# Altium schematic sheet S05_CPU_RevF.SchDoc
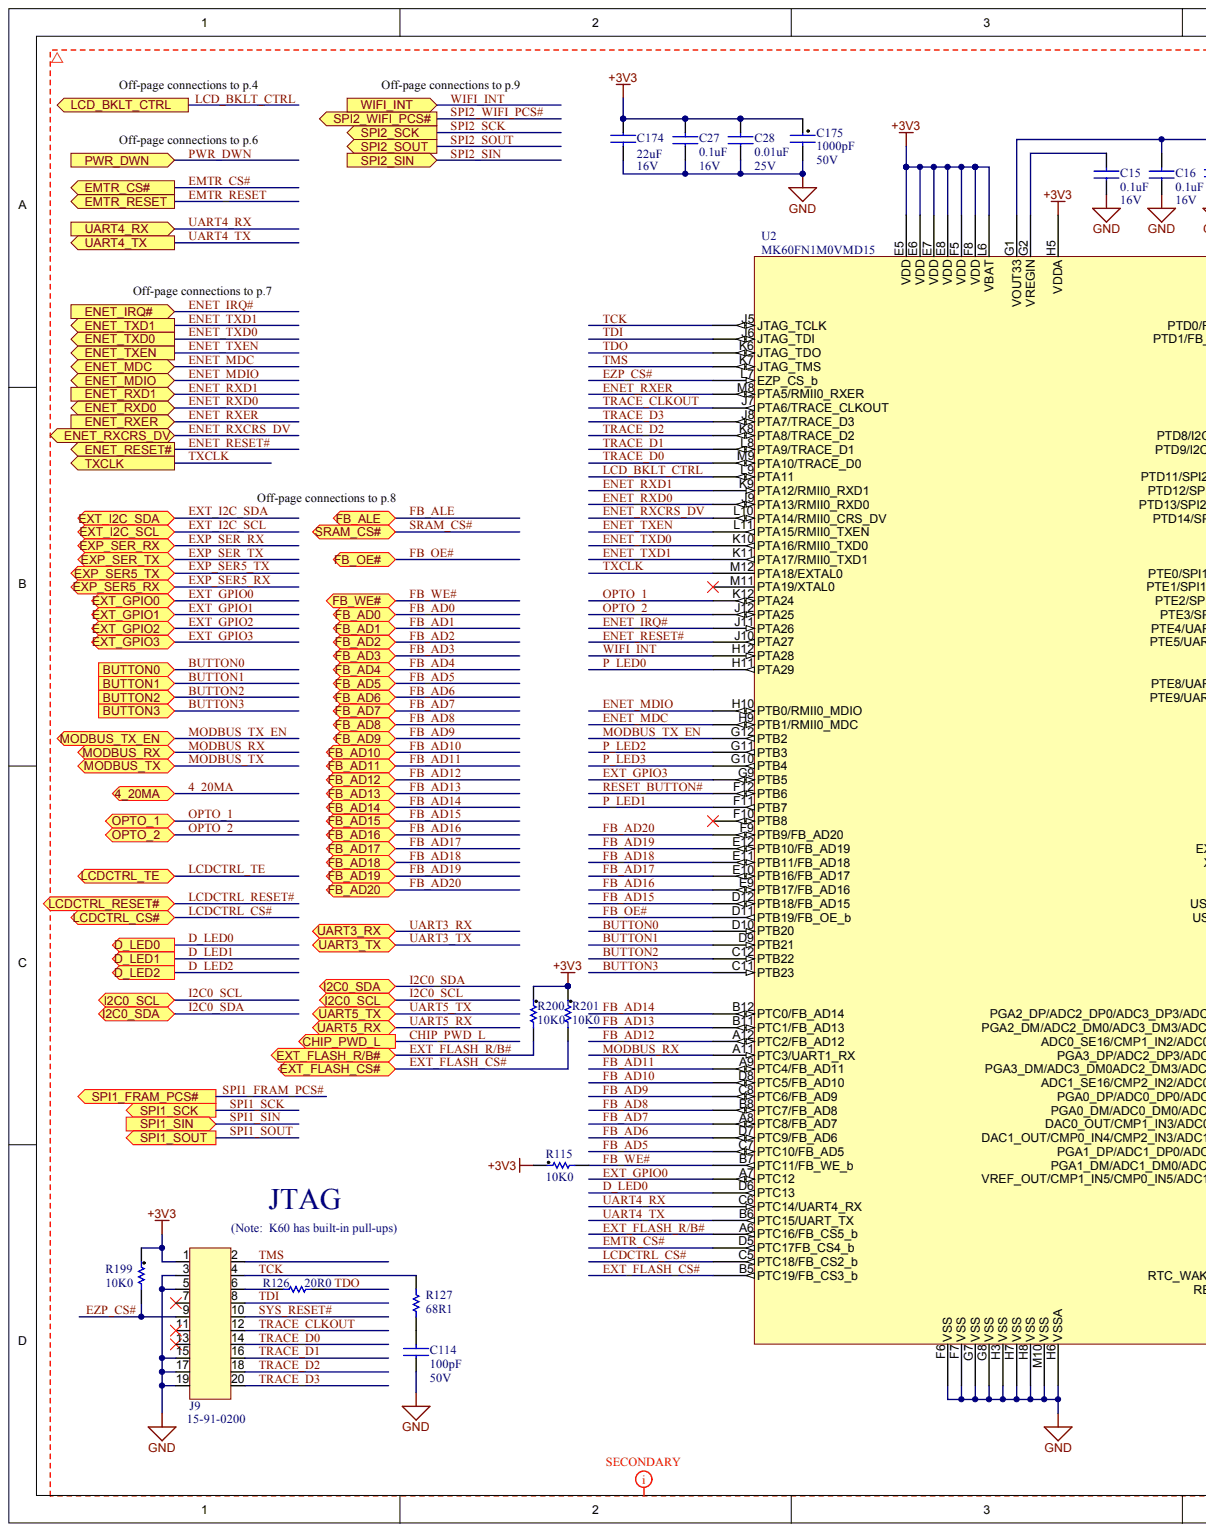
[diagram p0: S05_CPU_RevF.SchDoc, left]
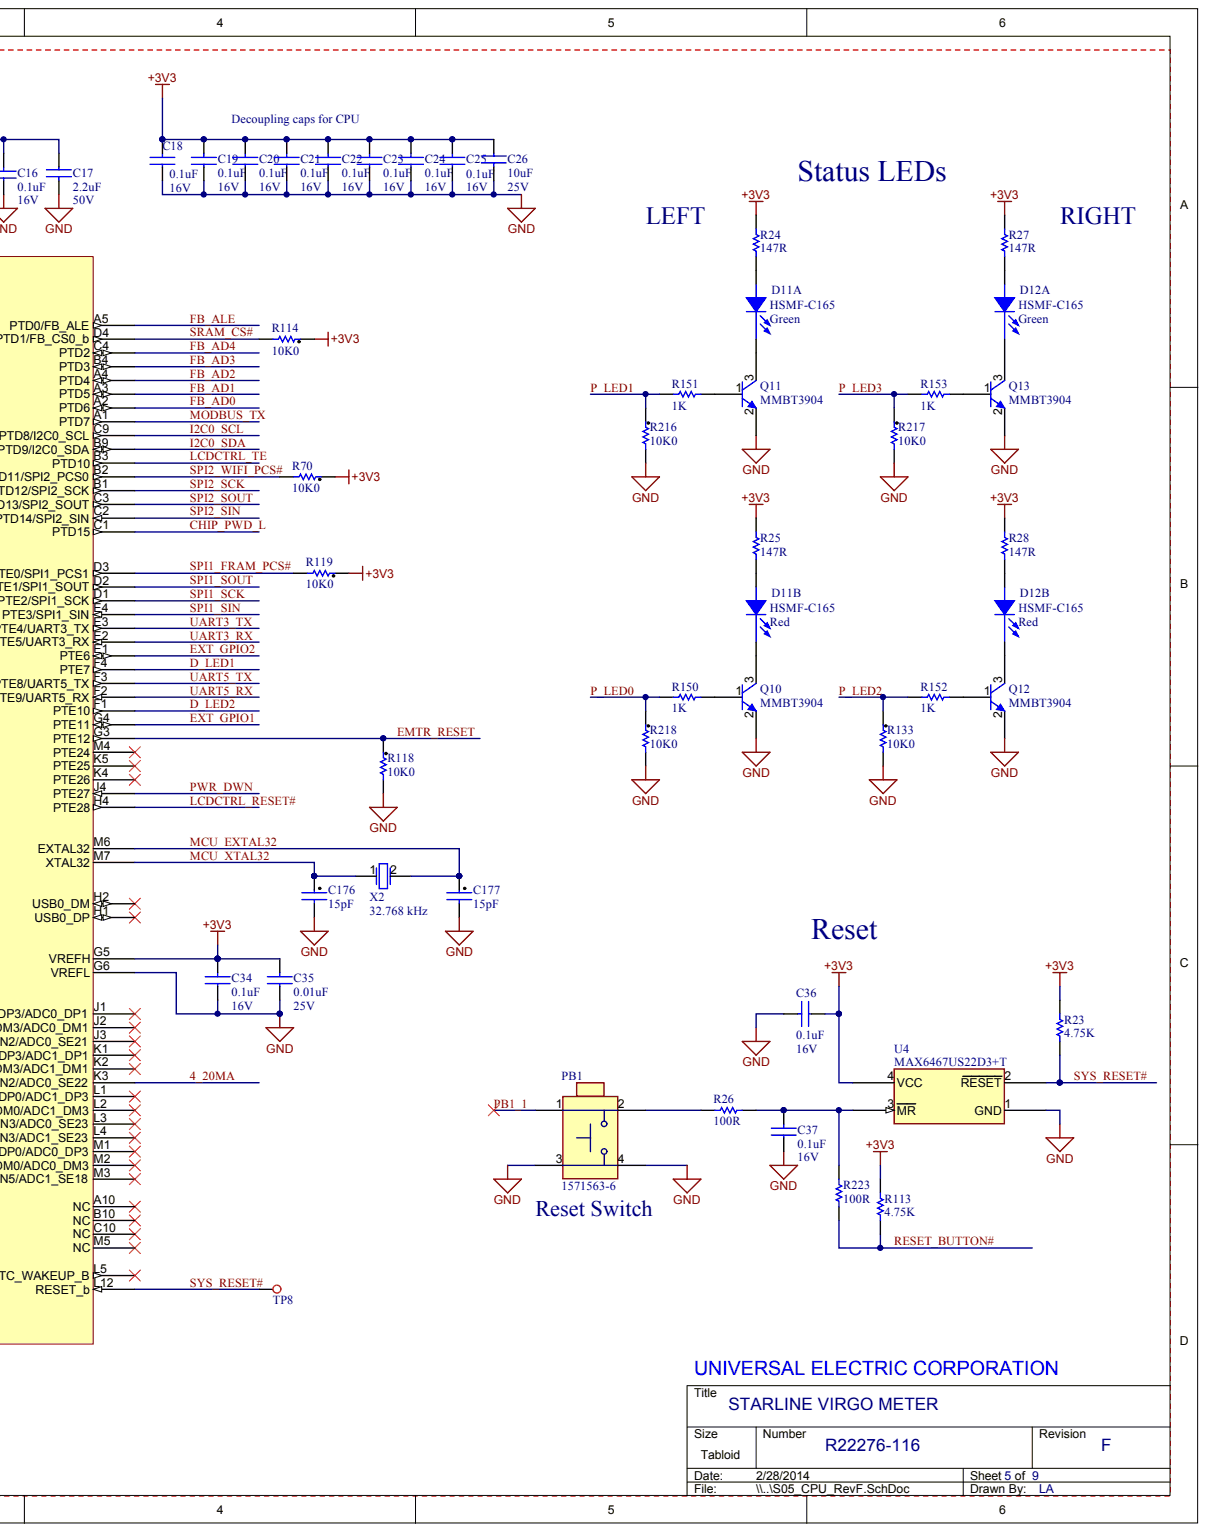
[diagram p1: S05_CPU_RevF.SchDoc, right]

## Parts
C15  value 0.1uF  Voltage: 16V  (hidden comment: CAP CER 0.1uF X5R 10% 16V SM_0402)
C16  value 0.1uF  Voltage: 16V  (hidden comment: CAP CER 0.1uF X5R 10% 16V SM_0402)
C17  Voltage: 50V  (hidden comment: 2.2uF)
C18  value 0.1uF  Voltage: 16V  (hidden comment: CAP CER 0.1uF X5R 10% 16V SM_0402)
C19  value 0.1uF  Voltage: 16V  (hidden comment: CAP CER 0.1uF X5R 10% 16V SM_0402)
C20  value 0.1uF  Voltage: 16V  (hidden comment: CAP CER 0.1uF X5R 10% 16V SM_0402)
C21  value 0.1uF  Voltage: 16V  (hidden comment: CAP CER 0.1uF X5R 10% 16V SM_0402)
C22  value 0.1uF  Voltage: 16V  (hidden comment: CAP CER 0.1uF X5R 10% 16V SM_0402)
C23  value 0.1uF  Voltage: 16V  (hidden comment: CAP CER 0.1uF X5R 10% 16V SM_0402)
C24  value 0.1uF  Voltage: 16V  (hidden comment: CAP CER 0.1uF X5R 10% 16V SM_0402)
C25  value 0.1uF  Voltage: 16V  (hidden comment: CAP CER 0.1uF X5R 10% 16V SM_0402)
C26  Voltage: 25V  (hidden comment: 10uF)
C27  value 0.1uF  Voltage: 16V  (hidden comment: CAP CER 0.1uF X5R 10% 16V SM_0402)
C28  value 0.01uF  Voltage: 25V  (hidden comment: CAP CER 0.01uF X7R 10% 25V SM_0402)
C34  value 0.1uF  Voltage: 16V  (hidden comment: CAP CER 0.1uF X5R 10% 16V SM_0402)
C35  value 0.01uF  Voltage: 25V  (hidden comment: CAP CER 0.01uF X7R 10% 25V SM_0402)
C36  value 0.1uF  Voltage: 16V  (hidden comment: CAP CER 0.1uF X5R 10% 16V SM_0402)
C37  value 0.1uF  Voltage: 16V  (hidden comment: CAP CER 0.1uF X5R 10% 16V SM_0402)
C114  value 100pF  Voltage: 50V  (hidden comment: CAP CER 100pF C0G 5% 50V SM_0402)
C174  Voltage: 16V  (hidden comment: 22uF)
C175  Voltage: 50V  (hidden comment: 1000pF)
C176  15pF
C177  15pF
D11  MFG_PART_NO: HSMF-C165  (hidden comment: Green)  (parts A,B)
D12  MFG_PART_NO: HSMF-C165  (hidden comment: Green)  (parts A,B)
J9  MFG_PART_NO2: 15-91-0200  (hidden comment: NUV_20CONN_Dual)
PB1  1571563-6
Q10  MMBT3904
Q11  MMBT3904
Q12  MMBT3904
Q13  MMBT3904
R23  value 4.75K  (hidden comment: RES 4.75K 1/16W 1% SM_0402)
R24  value 147R  (hidden comment: RES 147R 1/16W 1% SM_0402)
R25  value 147R  (hidden comment: RES 147R 1/16W 1% SM_0402)
R26  value 100R  (hidden comment: RES 100R 1/16W 1% SM_0402)
R27  value 147R  (hidden comment: RES 147R 1/16W 1% SM_0402)
R28  value 147R  (hidden comment: RES 147R 1/16W 1% SM_0402)
R70  10K0
R113  value 4.75K  (hidden comment: RES 4.75K 1/16W 1% SM_0402)
R114  10K0
R115  10K0
R118  10K0
R119  10K0
R126  value 20R0  (hidden comment: RES 20R0 1/16W 1% SM_0402)
R127  value 68R1  (hidden comment: RES 68R1 1/16W 1% SM_0402)
R133  10K0
R150  value 1K  (hidden comment: RES 1K 1/16W 1% SM_0402)
R151  value 1K  (hidden comment: RES 1K 1/16W 1% SM_0402)
R152  value 1K  (hidden comment: RES 1K 1/16W 1% SM_0402)
R153  value 1K  (hidden comment: RES 1K 1/16W 1% SM_0402)
R199  10K0
R200  10K0
R201  10K0
R216  10K0
R217  10K0
R218  10K0
R223  value 100R  (hidden comment: RES 100R 1/16W 1% SM_0402)
TP8  =VALUE
U2  MK60FN1M0VMD15
U4  MFG_PART_NO: MAX6467US22D3+T
X2  32.768 kHz

## Ports
4_20MA, BUTTON0, BUTTON1, BUTTON2, BUTTON3, CHIP_PWD_L, D_LED0, D_LED1, D_LED2, EMTR_CS#, EMTR_RESET, ENET_IRQ#, ENET_MDC, ENET_MDIO, ENET_RESET#, ENET_RXCRS_DV, ENET_RXD0, ENET_RXD1, ENET_RXER, ENET_TXD0, ENET_TXD1, ENET_TXEN, EXP_SER5_RX, EXP_SER5_TX, EXP_SER_RX, EXP_SER_TX, EXT_FLASH_CS#, EXT_FLASH_R/B#, EXT_GPIO0, EXT_GPIO1, EXT_GPIO2, EXT_GPIO3, EXT_I2C_SCL, EXT_I2C_SDA, FB_AD0, FB_AD1, FB_AD2, FB_AD3, FB_AD4, FB_AD5, FB_AD6, FB_AD7, FB_AD8, FB_AD9, FB_AD10, FB_AD11, FB_AD12, FB_AD13, FB_AD14, FB_AD15, FB_AD16, FB_AD17, FB_AD18, FB_AD19, FB_AD20, FB_ALE, FB_OE#, FB_WE#, I2C0_SCL, I2C0_SDA, LCD_BKLT_CTRL, LCDCTRL_CS#, LCDCTRL_RESET#, LCDCTRL_TE, MODBUS_RX, MODBUS_TX, MODBUS_TX_EN, OPTO_1, OPTO_2, PWR_DWN, SPI1_FRAM_PCS#, SPI1_SCK, SPI1_SIN, SPI1_SOUT, SPI2_SCK, SPI2_SIN, SPI2_SOUT, SPI2_WIFI_PCS#, SRAM_CS#, TXCLK, UART3_RX, UART3_TX, UART4_RX, UART4_TX, UART5_RX, UART5_TX, WIFI_INT

## Nets
+3V3: C18.2 C19.2 C20.2 C21.2 C22.2 C23.2 C24.2 C25.2 C26.1 C27.2 C28.2 C34.2 C35.2 C36.1 C174.1 C175.1 J9.1 R23.2 R24.2 R25.2 R27.2 R28.2 R70.1 R113.2 R114.1 R115.1 R119.1 R199.1 R200.1 R201.1 U2.E5(VDD) U2.E6(VDD) U2.E7(VDD) U2.E8(VDD) U2.F5(VDD) U2.F8(VDD) U2.G5(VREFH) U2.H5(VDDA) U2.L6(VBAT) U4.4(VCC)
GND: C15.1 C16.1 C17.2 C18.1 C19.1 C20.1 C21.1 C22.1 C23.1 C24.1 C25.1 C26.2 C27.1 C28.1 C34.1 C35.1 C36.2 C37.1 C114.1 C174.2 C175.2 C176.2 C177.2 J9.3 J9.5 J9.15 J9.17 J9.19 PB1.3 PB1.4 Q10.2 Q11.2 Q12.2 Q13.2 R118.2 R133.2 R216.2 R217.2 R218.2 U2.F6(VSS) U2.F7(VSS) U2.G6(VREFL) U2.G7(VSS) U2.G8(VSS) U2.H3(VSS) U2.H6(VSSA) U2.H7(VSS) U2.H8(VSS) U2.M10(VSS) U4.1(GND)
4_20MA: U2.K3(ADC1_SE16/CMP2_IN2/ADC0_SE22) ; port 4_20MA
BUTTON0: U2.D10(PTB20) ; port BUTTON0
BUTTON1: U2.D9(PTB21) ; port BUTTON1
BUTTON2: U2.C12(PTB22) ; port BUTTON2
BUTTON3: U2.C11(PTB23) ; port BUTTON3
CHIP_PWD_L: U2.C1(PTD15) ; port CHIP_PWD_L
D_LED0: U2.D6(PTC13) ; port D_LED0
D_LED1: U2.F4(PTE7) ; port D_LED1
D_LED2: U2.F1(PTE10) ; port D_LED2
EMTR_CS#: U2.D5(PTC17FB_CS4_b) ; port EMTR_CS#
EMTR_RESET: R118.1 U2.G3(PTE12) ; port EMTR_RESET
ENET_IRQ#: U2.J11(PTA26) ; port ENET_IRQ#
ENET_MDC: U2.H9(PTB1/RMII0_MDC) ; port ENET_MDC
ENET_MDIO: U2.H10(PTB0/RMII0_MDIO) ; port ENET_MDIO
ENET_RESET#: U2.J10(PTA27) ; port ENET_RESET#
ENET_RXCRS_DV: U2.L10(PTA14/RMII0_CRS_DV) ; port ENET_RXCRS_DV
ENET_RXD0: U2.J9(PTA13/RMII0_RXD0) ; port ENET_RXD0
ENET_RXD1: U2.K9(PTA12/RMII0_RXD1) ; port ENET_RXD1
ENET_RXER: U2.M8(PTA5/RMII0_RXER) ; port ENET_RXER
ENET_TXD0: U2.K10(PTA16/RMII0_TXD0) ; port ENET_TXD0
ENET_TXD1: U2.K11(PTA17/RMII0_TXD1) ; port ENET_TXD1
ENET_TXEN: U2.L11(PTA15/RMII0_TXEN) ; port ENET_TXEN
EXT_FLASH_CS#: R201.2 U2.B5(PTC19/FB_CS3_b) ; port EXT_FLASH_CS#
EXT_FLASH_R/B#: R200.2 U2.A6(PTC16/FB_CS5_b) ; port EXT_FLASH_R/B#
EXT_GPIO0: U2.A7(PTC12) ; port EXT_GPIO0
EXT_GPIO1: U2.G4(PTE11) ; port EXT_GPIO1
EXT_GPIO2: U2.E1(PTE6) ; port EXT_GPIO2
EXT_GPIO3: U2.G9(PTB5) ; port EXT_GPIO3
EZP_CS#: J9.9 R199.2 U2.L7(EZP_CS_b)
FB_AD0: U2.A2(PTD6) ; port FB_AD0
FB_AD1: U2.A3(PTD5) ; port FB_AD1
FB_AD2: U2.A4(PTD4) ; port FB_AD2
FB_AD3: U2.B4(PTD3) ; port FB_AD3
FB_AD4: U2.C4(PTD2) ; port FB_AD4
FB_AD5: U2.C7(PTC10/FB_AD5) ; port FB_AD5
FB_AD6: U2.D7(PTC9/FB_AD6) ; port FB_AD6
FB_AD7: U2.A8(PTC8/FB_AD7) ; port FB_AD7
FB_AD8: U2.B8(PTC7/FB_AD8) ; port FB_AD8
FB_AD9: U2.C8(PTC6/FB_AD9) ; port FB_AD9
FB_AD10: U2.D8(PTC5/FB_AD10) ; port FB_AD10
FB_AD11: U2.A9(PTC4/FB_AD11) ; port FB_AD11
FB_AD12: U2.A12(PTC2/FB_AD12) ; port FB_AD12
FB_AD13: U2.B11(PTC1/FB_AD13) ; port FB_AD13
FB_AD14: U2.B12(PTC0/FB_AD14) ; port FB_AD14
FB_AD15: U2.D12(PTB18/FB_AD15) ; port FB_AD15
FB_AD16: U2.E9(PTB17/FB_AD16) ; port FB_AD16
FB_AD17: U2.E10(PTB16/FB_AD17) ; port FB_AD17
FB_AD18: U2.E11(PTB11/FB_AD18) ; port FB_AD18
FB_AD19: U2.E12(PTB10/FB_AD19) ; port FB_AD19
FB_AD20: U2.F9(PTB9/FB_AD20) ; port FB_AD20
FB_ALE: U2.A5(PTD0/FB_ALE) ; port FB_ALE
FB_OE#: U2.D11(PTB19/FB_OE_b) ; port FB_OE#
FB_WE#: R115.2 U2.B7(PTC11/FB_WE_b) ; port FB_WE#
I2C0_SCL: U2.C9(PTD8/I2C0_SCL) ; port I2C0_SCL
I2C0_SDA: U2.B9(PTD9/I2C0_SDA) ; port I2C0_SDA
LCD_BKLT_CTRL: U2.L9(PTA11) ; port LCD_BKLT_CTRL
LCDCTRL_CS#: U2.C5(PTC18/FB_CS2_b) ; port LCDCTRL_CS#
LCDCTRL_RESET#: U2.H4(PTE28) ; port LCDCTRL_RESET#
LCDCTRL_TE: U2.B3(PTD10) ; port LCDCTRL_TE
MCU_EXTAL32: C177.1 U2.M6(EXTAL32) X2.2
MCU_XTAL32: C176.1 U2.M7(XTAL32) X2.1
MODBUS_RX: U2.A11(PTC3/UART1_RX) ; port MODBUS_RX
MODBUS_TX: U2.A1(PTD7) ; port MODBUS_TX
MODBUS_TX_EN: U2.G12(PTB2) ; port MODBUS_TX_EN
OPTO_1: U2.K12(PTA24) ; port OPTO_1
OPTO_2: U2.J12(PTA25) ; port OPTO_2
P_LED0: R150.1 R218.1 U2.H11(PTA29)
P_LED1: R151.1 R216.1 U2.F11(PTB7)
P_LED2: R133.1 R152.1 U2.G11(PTB3)
P_LED3: R153.1 R217.1 U2.G10(PTB4)
PB1_1: PB1.1
PWR_DWN: U2.J4(PTE27) ; port PWR_DWN
RESET_BUTTON#: R113.1 R223.1 U2.F12(PTB6)
SPI1_FRAM_PCS#: R119.2 U2.D3(PTE0/SPI1_PCS1) ; port SPI1_FRAM_PCS#
SPI1_SCK: U2.D1(PTE2/SPI1_SCK) ; port SPI1_SCK
SPI1_SIN: U2.E4(PTE3/SPI1_SIN) ; port SPI1_SIN
SPI1_SOUT: U2.D2(PTE1/SPI1_SOUT) ; port SPI1_SOUT
SPI2_SCK: U2.B1(PTD12/SPI2_SCK) ; port SPI2_SCK
SPI2_SIN: U2.C2(PTD14/SPI2_SIN) ; port SPI2_SIN
SPI2_SOUT: U2.C3(PTD13/SPI2_SOUT) ; port SPI2_SOUT
SPI2_WIFI_PCS#: R70.2 U2.B2(PTD11/SPI2_PCS0) ; port SPI2_WIFI_PCS#
SRAM_CS#: R114.2 U2.D4(PTD1/FB_CS0_b) ; port SRAM_CS#
SYS_RESET#: J9.10 R23.1 TP8.1 U2.L12(RESET_b) U4.2(RESET)
TCK: J9.4 R127.2 U2.J5(JTAG_TCLK)
TDI: J9.8 U2.J6(JTAG_TDI)
TDO: R126.2 U2.K6(JTAG_TDO)
TMS: J9.2 U2.K7(JTAG_TMS)
TRACE_CLKOUT: J9.12 U2.J7(PTA6/TRACE_CLKOUT)
TRACE_D0: J9.14 U2.M9(PTA10/TRACE_D0)
TRACE_D1: J9.16 U2.L8(PTA9/TRACE_D1)
TRACE_D2: J9.18 U2.K8(PTA8/TRACE_D2)
TRACE_D3: J9.20 U2.J8(PTA7/TRACE_D3)
TXCLK: U2.M12(PTA18/EXTAL0) ; port TXCLK
UART3_RX: U2.E2(PTE5/UART3_RX) ; port UART3_RX
UART3_TX: U2.E3(PTE4/UART3_TX) ; port UART3_TX
UART4_RX: U2.C6(PTC14/UART4_RX) ; port UART4_RX
UART4_TX: U2.B6(PTC15/UART_TX) ; port UART4_TX
UART5_RX: U2.F2(PTE9/UART5_RX) ; port UART5_RX
UART5_TX: U2.F3(PTE8/UART5_TX) ; port UART5_TX
WIFI_INT: U2.H12(PTA28) ; port WIFI_INT
NetC15_2: C15.2 U2.G2(VREGIN)
NetC16_2: C16.2 C17.1 U2.G1(VOUT33)
NetC37_2: C37.2 R26.2 R223.2 U4.3(MR)
NetC114_2: C114.2 R127.1
NetD11_A1: D11.A1 R24.1
NetD11_A2: D11.A2 R25.1
NetD11_K1: D11.K1 Q11.3
NetD11_K2: D11.K2 Q10.3
NetD12_A1: D12.A1 R27.1
NetD12_A2: D12.A2 R28.1
NetD12_K1: D12.K1 Q13.3
NetD12_K2: D12.K2 Q12.3
NetJ9_6: J9.6 R126.1
NetPB1_2: PB1.2 R26.1
NetQ10_1: Q10.1 R150.2
NetQ11_1: Q11.1 R151.2
NetQ12_1: Q12.1 R152.2
NetQ13_1: Q13.1 R153.2
EXP_SER5_RX: ; port EXP_SER5_RX
EXP_SER5_TX: ; port EXP_SER5_TX
EXP_SER_RX: ; port EXP_SER_RX
EXP_SER_TX: ; port EXP_SER_TX
EXT_I2C_SCL: ; port EXT_I2C_SCL
EXT_I2C_SDA: ; port EXT_I2C_SDA
N00176:

Not connected: J9 7 11 13; U2 A10(NC) B10(NC) C10(NC) F10(PTB8) H1(USB0_DP) H2(USB0_DM) J1(PGA2_DP/ADC2_DP0/ADC3_DP3/ADC0_DP1) J2(PGA2_DM/ADC2_DM0/ADC3_DM3/ADC0_DM1) J3(ADC0_SE16/CMP1_IN2/ADC0_SE21) K1(PGA3_DP/ADC2_DP3/ADC1_DP1) K2(PGA3_DM/ADC3_DM0ADC2_DM3/ADC1_DM1) K4(PTE26) K5(PTE25) L1(PGA0_DP/ADC0_DP0/ADC1_DP3) L2(PGA0_DM/ADC0_DM0/ADC1_DM3) L3(DAC0_OUT/CMP1_IN3/ADC0_SE23) L4(DAC1_OUT/CMP0_IN4/CMP2_IN3/ADC1_SE23) L5(RTC_WAKEUP_B) M1(PGA1_DP/ADC1_DP0/ADC0_DP3) M2(PGA1_DM/ADC1_DM0/ADC0_DM3) M3(VREF_OUT/CMP1_IN5/CMP0_IN5/ADC1_SE18) M4(PTE24) M5(NC) M11(PTA19/XTAL0)

## Library data of the parts
C15 C16 C18-C25 C27 C34 C36 C37 = (no symbol name): MFG_PART_NO: GRM155R71C104KA88D; MFG: Murata
C17 = (no symbol name): MFG_PART_NO: C2012X5R1H225K; MFG: TDK; Value: 2.2uF
C26 = (no symbol name): MFG_PART_NO: C3216X7R1E106K; MFG: TDK; Value: 10uF
C28 C35 = (no symbol name): MFG_PART_NO: GRM155R71E103KA01D; MFG: Murata
C114 = (no symbol name): MFG_PART_NO: GRM1555C1H101JZ01D; MFG: Murata
C174 = (no symbol name): MFG_PART_NO: C2012X5R1C226K; MFG: TDK; Value: 22uF
C175 = (no symbol name): MFG_PART_NO: C1005X7R1H102K050BA; MFG: Yageo; Value: 1000pF
C176 C177 = (no symbol name): Manufacturer P/N: C1005C0G1H150J; Manufacturer: TDK; Voltage-Rated: 50V; Tolerance: 0.05; Package: SM_0402; Capacitance: 15pF
D11 = (no symbol name): LEVEL: Level_1; PCBLIB: UEC01.PcbLib; MFG: Avago Technologies US Inc.; TYPE: Diode; VOLTAGE: 2.1V; CURRENT: 20mA; NUV_DESCRIPTION: LED BICOLOR HER/GN DIFF 0603 SMD; POSITION: LEFT; VALUE: Green; PACKAGE: SMT; ROHS: RoHS; Created By: N.Wennyk
D12 = (no symbol name): LEVEL: Level_1; PCBLIB: UEC01.PcbLib; MFG: Avago Technologies US Inc.; TYPE: Diode; VOLTAGE: 2.1V; CURRENT: 20mA; NUV_DESCRIPTION: LED BICOLOR HER/GN DIFF 0603 SMD; POSITION: RIGHT; VALUE: Green; PACKAGE: SMT; ROHS: RoHS; Created By: N.Wennyk
J9 = (no symbol name): MFG_PART_NO: FTSH-110-01-L-DV; SYM_LVL: LEVEL 1; LEVEL: Level_1; PCBLIB: UEC01.PcbLib; MFG: Samtec; VALUE: FTSH-110-01-L-DV; NUV_DESCRIPTION: CONN HEADER 20POS .050" SMT; ROOM: *; NOSTUFF: *; MFG2: Molex; TYPE: Connector; PACKAGE: SMT; ROHS: RoHS; LAST_UPDATE: 6/21/2012; Checked By: N.Wennyk; Created By: K Desrochers
PB1 = (no symbol name): LEVEL: Level_1; PCBLIB: UEC01.PcbLib; MFG: TE Connectivity; NUV_DESCRIPTION: Switch Tactile OFF (ON) SPST Round Button Gull Wing 0.05A 12VDC 1VA 2.55N SMD; MFG_PART_NO: 1571563-6; TYPE: Switch/button; VALUE: 1571563-6; PACKAGE: SMT; ROHS: RoHS; LAST_UPDATE: 1/14/2013; Created By: M Ball
Q10-Q13 = (no symbol name): LEVEL: Level_1; MFG: Fairchild Semiconductor; TYPE: NPN BJT; VOLTAGE: 40V; CURRENT: 200mA; NUV_DESCRIPTION: TRANSISTOR GP NPN AMP SOT-23; MFG_PART_NO: MMBT3904; VALUE: MMBT3904; PACKAGE: SMT; ROHS: RoHS; LAST_UPDATE: 7/26/2012; Created By: K Desrochers
R23 R113 = (no symbol name): MFG_PART_NO: CRCW04024K75FKED; MFG: Vishay
R24 R25 R27 R28 = (no symbol name): MFG_PART_NO: CRCW0402147RFKED; MFG: Vishay
R26 R223 = (no symbol name): MFG_PART_NO: CRCW0402100RFKED; MFG: Vishay
R70 R114 R115 R118 R119 R133 R199-R201 R216-R218 = (no symbol name): Part Number: CRCW040210K0FKED; Manufacturer: Vishay; Power: 1/16W; Tolerance: 0.01; RESISTANCE: 10K0; Manufacturer P/N: CRCW040210K0FKED; Package: SMT; Type: Resistor
R126 = (no symbol name): MFG_PART_NO: CRCW040220R0FKED; MFG: Vishay
R127 = (no symbol name): MFG_PART_NO: CRCW040268R1FKED; MFG: Vishay
R150-R153 = (no symbol name): MFG_PART_NO: CRCW04021K00FKED; MFG: Vishay
TP8 = (no symbol name): SYM_LVL: LEVEL1; LEVEL: Level_1; NUV_DESCRIPTION: Test Point; ROOM: *; NOSTUFF: NOSTUFF; TYPE: Test Point; PACKAGE: SMT; ROHS: RoHS; LAST_UPDATE: 6/21/2012; Created By: K Desrochers
U2 = (no symbol name): MFG: Freescale; VALUE: MK60FN1M0VMD15; MFG_PART_NO: MK60FN1M0VMD15
U4 = (no symbol name): LEVEL: Level_1; MFG: Maxim; NUV_DESCRIPTION: IC MPU/RESET CIRC 2.188V SOT143; TYPE: IC; PACKAGE: SMT; ROHS: RoHS; LAST_UPDATE: 6/26/2012; Comment: =VALUE; Created By: K Desrochers
X2 = (no symbol name): LEVEL: Level_1; PCBLIB: UEC01.PcbLib; MFG: Abracon; NUV_DESCRIPTION: CRYSTAL 32.768 KHZ 12.5PF SMD; TYPE: Crystal; VALUE: 32.768 kHz; PACKAGE: SMT; ROHS: RoHS; LAST_UPDATE: 6/22/2012; Created By: K Desrochers
